# Altium schematic sheet MCU.SchDoc(MCU)
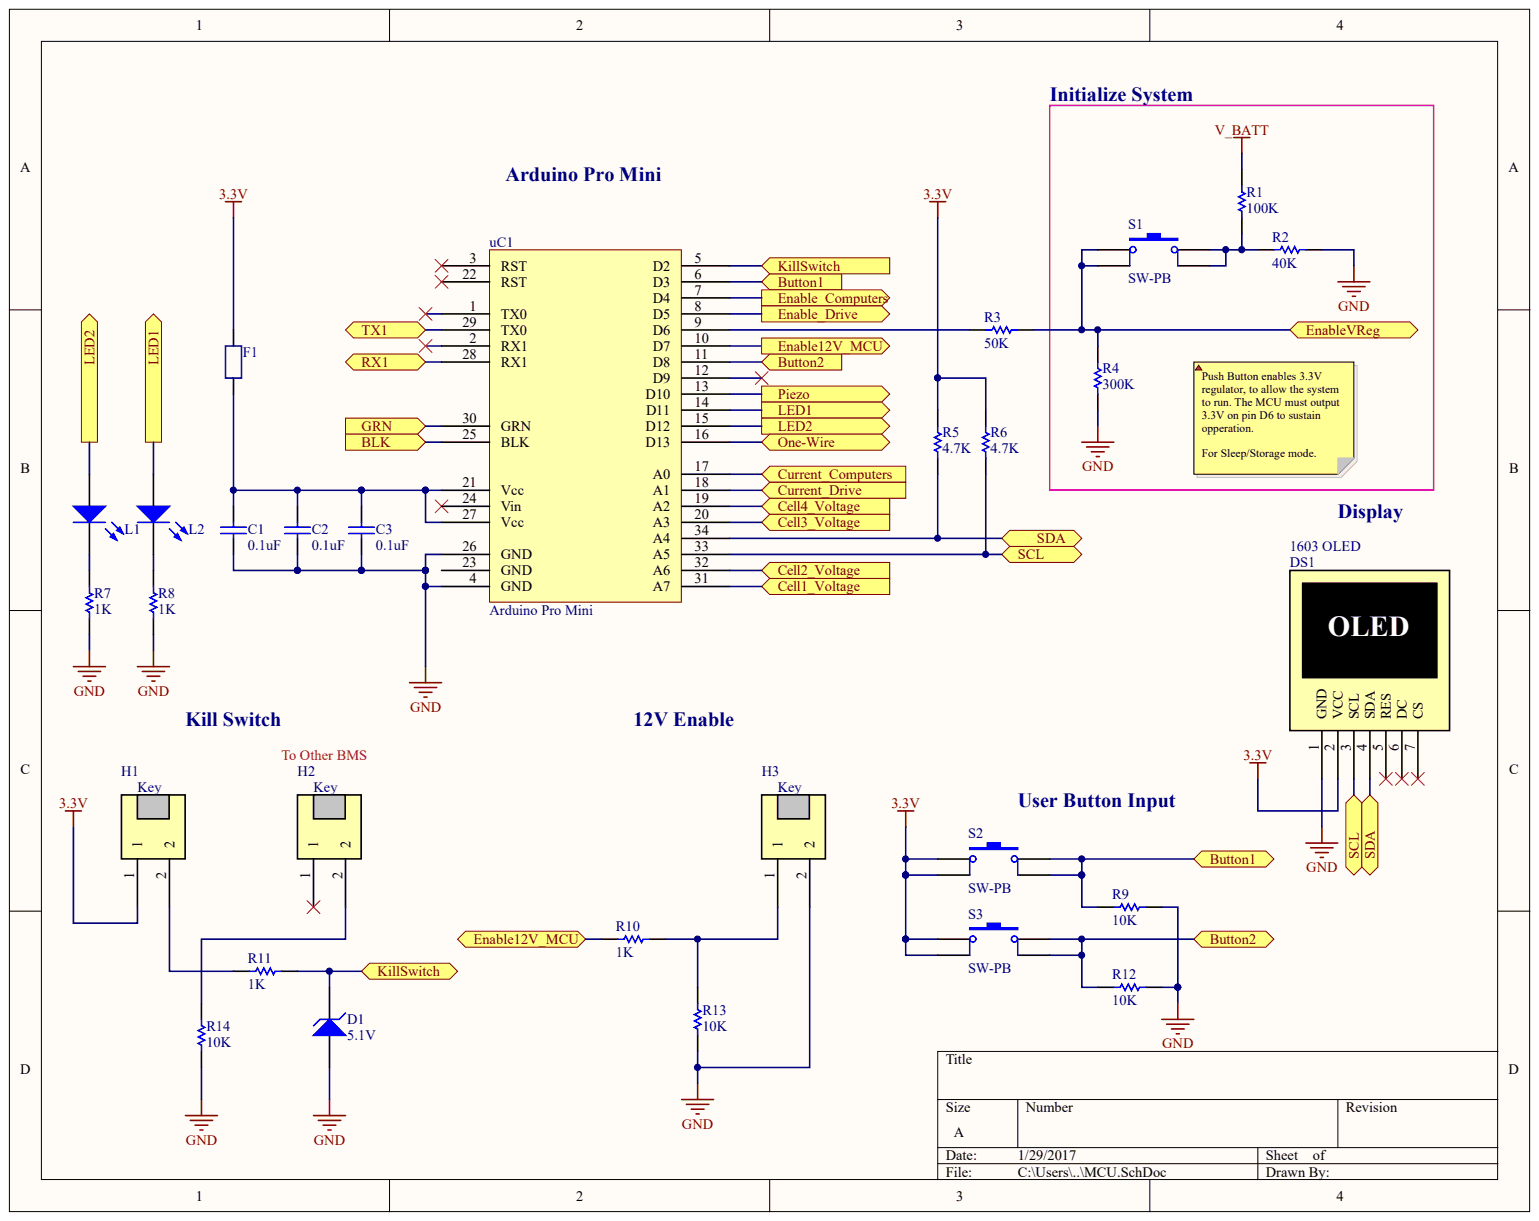
[diagram p0: MCU.SchDoc]

## Parts
C1  value 0.1uF  (hidden comment: Cap_0805)
C2  value 0.1uF  (hidden comment: Cap_0805)
C3  value 0.1uF  (hidden comment: Cap_0805)
D1  5.1V
DS1  1603 OLED
F1  FerriteBead_0805  (value not drawn)
H1  CONN HEADER VERT 2POS  (value not drawn)
H2  CONN HEADER VERT 2POS  (value not drawn)
H3  CONN HEADER VERT 2POS  (value not drawn)
L1  LED 0805 Green  (value not drawn)
L2  LED 0805 Red  (value not drawn)
R1  value 100K  (hidden comment: Res 0805)
R2  value 40K  (hidden comment: Res 0805)
R3  value 50K  (hidden comment: Res 0805)
R4  value 300K  (hidden comment: Res 0805)
R5  value 4.7K  (hidden comment: Res 0805)
R6  value 4.7K  (hidden comment: Res 0805)
R7  value 1K  (hidden comment: Res 0805)
R8  value 1K  (hidden comment: Res 0805)
R9  value 10K  (hidden comment: Res 0805)
R10  value 1K  (hidden comment: Res 0805)
R11  value 1K  (hidden comment: Res 0805)
R12  value 10K  (hidden comment: Res 0805)
R13  value 10K  (hidden comment: Res 0805)
R14  value 10K  (hidden comment: Res 0805)
S1  SW-PB
S2  SW-PB
S3  SW-PB
uC1  Arduino Pro Mini

## Ports
BLK, BUTTON1, BUTTON2, CELL1_VOLTAGE, CELL2_VOLTAGE, CELL3_VOLTAGE, CELL4_VOLTAGE, CURRENT_COMPUTERS, CURRENT_DRIVE, ENABLE12V_MCU, ENABLE_COMPUTERS, ENABLE_DRIVE, ENABLEVREG, GRN, KILLSWITCH, LED1, LED2, ONE-WIRE, PIEZO, RX1, SCL, SDA, TX1

## Nets
3.3V: DS1.2(VCC) F1.2 H1.1 R5.2 R6.2 S2.1 S2.3 S3.1 S3.3
BUTTON1: R9.2 S2.2 S2.4 uC1.6(D3) ; port BUTTON1
BUTTON2: R12.2 S3.2 S3.4 uC1.11(D8) ; port BUTTON2
CELL1_VOLTAGE: uC1.31(A7) ; port CELL1_VOLTAGE
CELL2_VOLTAGE: uC1.32(A6) ; port CELL2_VOLTAGE
CELL3_VOLTAGE: uC1.20(A3) ; port CELL3_VOLTAGE
CELL4_VOLTAGE: uC1.19(A2) ; port CELL4_VOLTAGE
CURRENT_COMPUTERS: uC1.17(A0) ; port CURRENT_COMPUTERS
CURRENT_DRIVE: uC1.18(A1) ; port CURRENT_DRIVE
ENABLE12V_MCU: R10.2 uC1.10(D7) ; port ENABLE12V_MCU
ENABLE_COMPUTERS: uC1.7(D4) ; port ENABLE_COMPUTERS
ENABLE_DRIVE: uC1.8(D5) ; port ENABLE_DRIVE
ENABLEVREG: R3.2 R4.2 S1.1 S1.3 ; port ENABLEVREG
GND: C1.1 C2.1 C3.1 D1.1 DS1.1(GND) H3.2 R2.2 R4.1 R7.1 R8.1 R9.1 R12.1 R13.1 R14.2 uC1.4(GND) uC1.26(GND)
KILLSWITCH: D1.2 R11.1 uC1.5(D2) ; port KILLSWITCH
ONE-WIRE: uC1.16(D13) ; port ONE-WIRE
PIEZO: uC1.13(D10) ; port PIEZO
V_BATT: R1.2
BLK: uC1.25(BLK) ; port BLK
GRN: uC1.30(GRN) ; port GRN
LED1: L2.1 uC1.14(D11) ; port LED1
LED2: L1.1 uC1.15(D12) ; port LED2
RX1: uC1.28(RX1) ; port RX1
SCL: DS1.3(SCL) R6.1 uC1.33(A5) ; port SCL
SDA: DS1.4(SDA) R5.1 uC1.34(A4) ; port SDA
TX1: uC1.29(TX0) ; port TX1
NetC1_2: C1.2 C2.2 C3.2 F1.1 uC1.21(Vcc) uC1.27(Vcc)
NetH1_2: H1.2 R11.2
NetH2_2: H2.2 R14.1
NetH3_1: H3.1 R10.1 R13.2
NetL1_2: L1.2 R7.2
NetL2_2: L2.2 R8.2
NetR1_1: R1.1 R2.1 S1.2 S1.4
NetR3_1: R3.1 uC1.9(D6)

Not connected: DS1 5(RES) 6(DC) 7(CS); H2 1; uC1 1(TX0) 2(RX1) 3(RST) 12(D9) 22(RST) 23(GND) 24(Vin)

## Library data of the parts
H1-H3 = (no symbol name): Model #: 640454-2
C1-C3 D1 DS1 F1 L1 L2 R1-R14 S1-S3 uC1 = (no symbol name)
  S1-S3: Published: 24-Mar-1999; LatestRevisionDate: 17-Jul-2002; LatestRevisionNote: Re-released for DXP Platform.; PackageReference: SPST-2; PackageDescription: Switch; 2 Leads; Publisher: Altium Limited
